# Altium schematic sheet Ethernet.SchDoc(Ethernet)
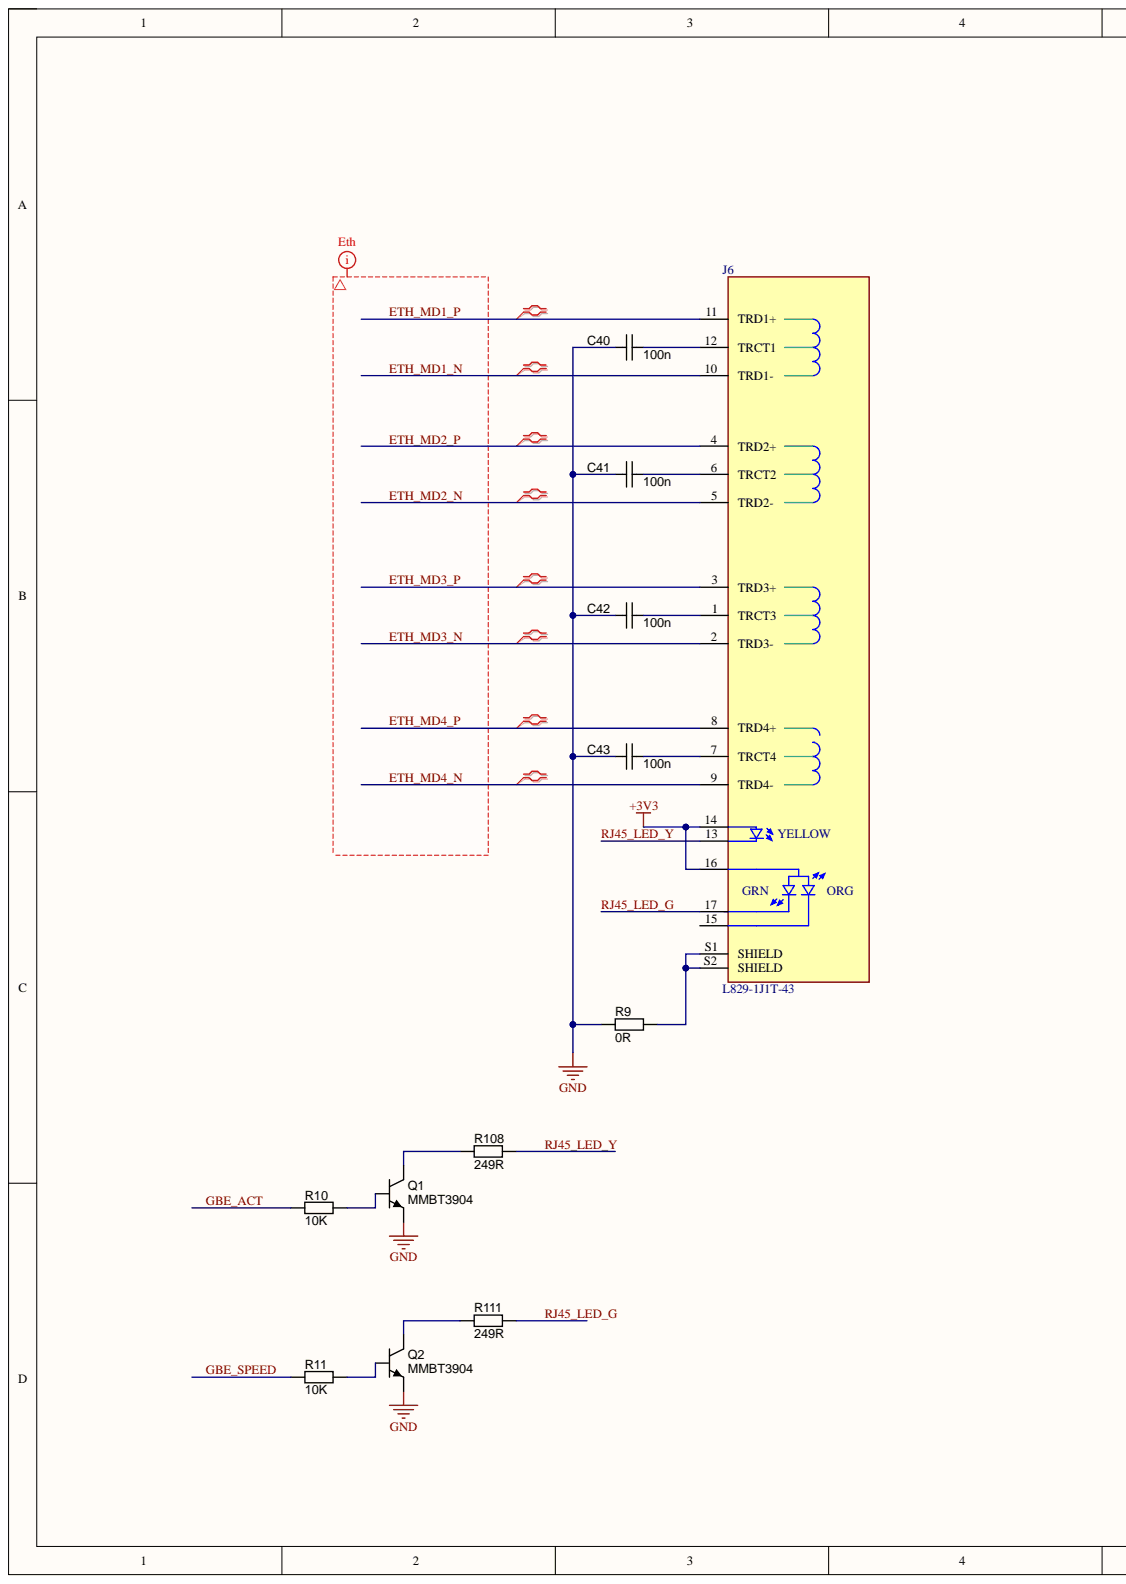
[diagram p0: Ethernet.SchDoc, left]
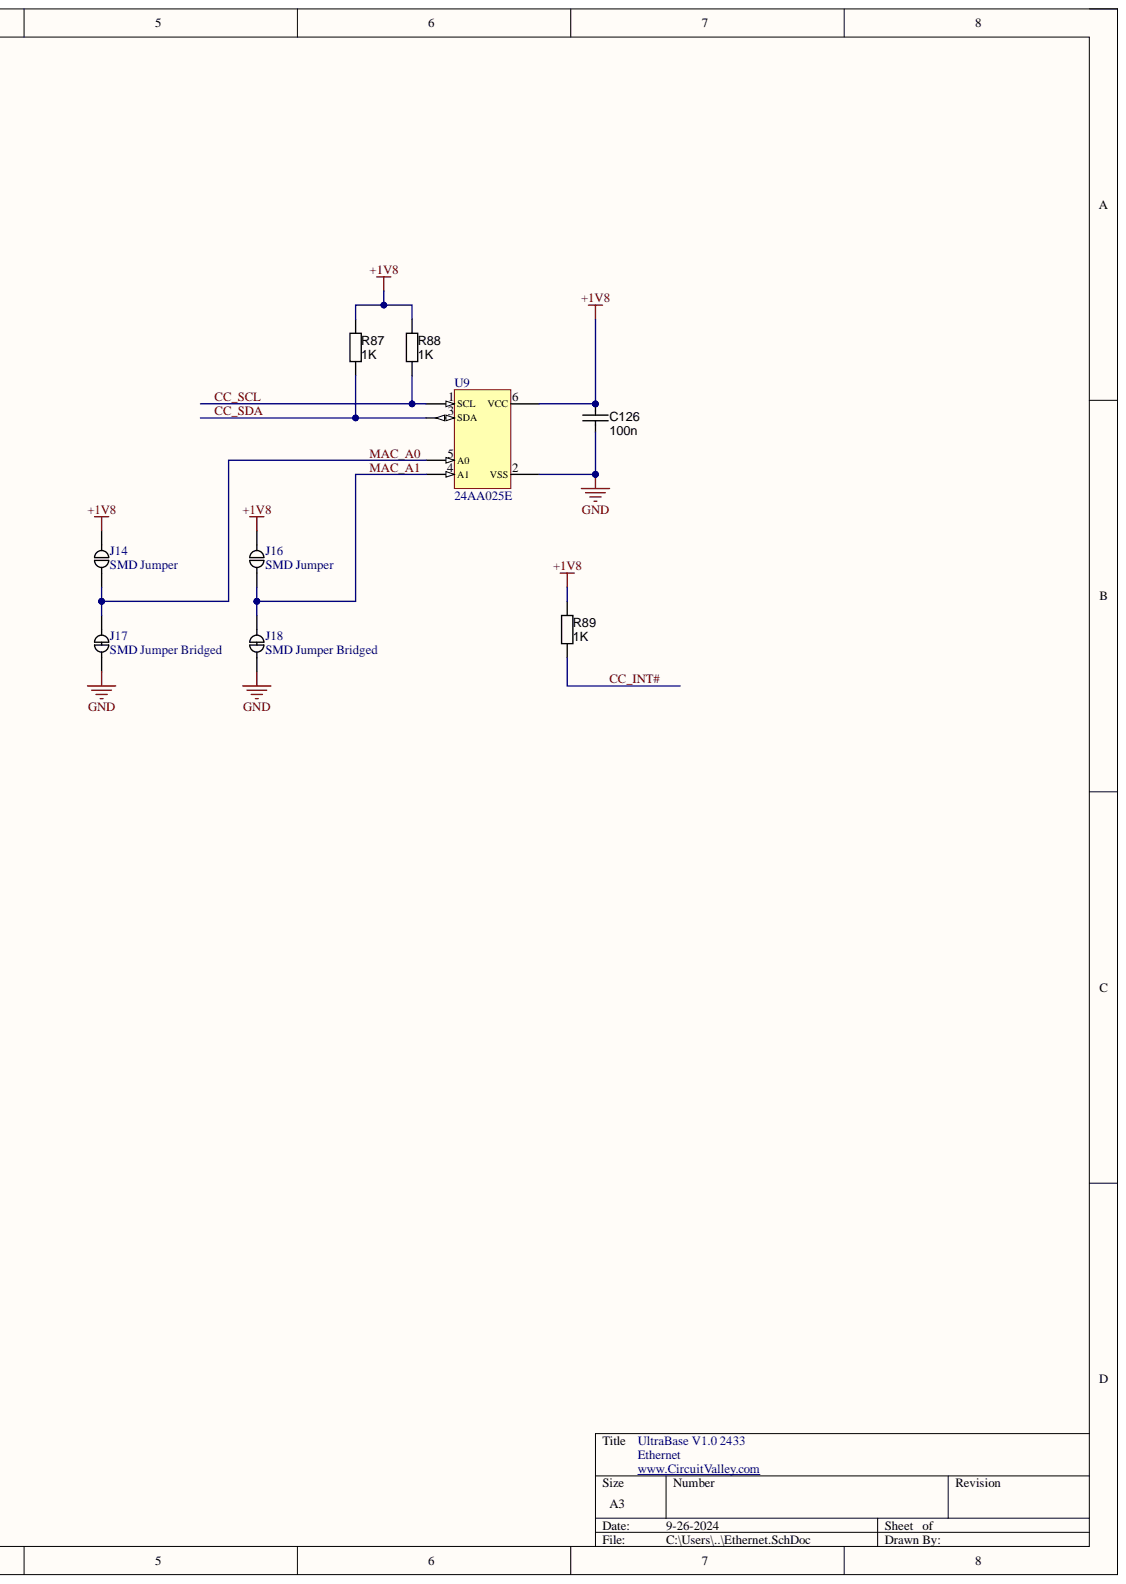
[diagram p1: Ethernet.SchDoc, right]

## Parts
C40  100n  [CAPC1608N]
C41  100n  [CAPC1608N]
C42  100n  [CAPC1608N]
C43  100n  [CAPC1608N]
C126  100n  [CAPC1608N]
J6  L829-1J1T-43  [L829-1J1T-43]
J14  SMD Jumper  [SMD_Jumper]
J16  SMD Jumper  [SMD_Jumper]
J17  SMD Jumper Bridged  [SMD_Jumper_bridge]
J18  SMD Jumper Bridged  [SMD_Jumper_bridge]
Q1  Manufacturer Part Number 1: MMBT3904  [SOT23-3]  (hidden comment: NPN_MMBT3904)
Q2  Manufacturer Part Number 1: MMBT3904  [SOT23-3]  (hidden comment: NPN_MMBT3904)
R9  value 0R  [RESC1608N]  (hidden comment: RES_NL_0603)
R10  value 10K  [RESC1608N]  (hidden comment: RES_NL_0603)
R11  value 10K  [RESC1608N]  (hidden comment: RES_NL_0603)
R87  value 1K  [RESC1608N]  (hidden comment: RES_NL_0603)
R88  value 1K  [RESC1608N]  (hidden comment: RES_NL_0603)
R89  value 1K  [RESC1608N]  (hidden comment: RES_NL_0603)
R108  value 249R  [RESC1608N]  (hidden comment: RES_NL_0603)
R111  value 249R  [RESC1608N]  (hidden comment: RES_NL_0603)
U9  24AA025E  [SOT23-6]

## Nets
+1V8: C126.2 J14.2 J16.2 R87.2 R88.2 R89.2 U9.6(VCC)
+3V3: J6.14 J6.16
GND: C40.1 C41.1 C42.1 C43.1 C126.1 J17.1 J18.1 Q1.2 Q2.2 R9.1 U9.2(VSS)
CC_INT#: R89.1
CC_SCL: R88.1 U9.1(SCL)
CC_SDA: R87.1 U9.3(SDA)
ETH_MD1_N: J6.10(TRD1-)
ETH_MD1_P: J6.11(TRD1+)
ETH_MD2_N: J6.5(TRD2-)
ETH_MD2_P: J6.4(TRD2+)
ETH_MD3_N: J6.2(TRD3-)
ETH_MD3_P: J6.3(TRD3+)
ETH_MD4_N: J6.9(TRD4-)
ETH_MD4_P: J6.8(TRD4+)
GBE_ACT: R10.1
GBE_SPEED: R11.1
MAC_A0: J14.1 J17.2 U9.5(A0)
MAC_A1: J16.1 J18.2 U9.4(A1)
RJ45_LED_G: J6.17 R111.2
RJ45_LED_Y: J6.13 R108.2
NetC40_2: C40.2 J6.12(TRCT1)
NetC41_2: C41.2 J6.6(TRCT2)
NetC42_2: C42.2 J6.1(TRCT3)
NetC43_2: C43.2 J6.7(TRCT4)
NetJ6_S1: J6.S1(SHIELD) J6.S2(SHIELD) R9.2
NetQ1_1: Q1.1 R10.2
NetQ1_3: Q1.3 R108.1
NetQ2_1: Q2.1 R11.2
NetQ2_3: Q2.3 R111.1

Not connected: J6 15

## Library data of the parts
C40-C43 C126 = CAPC_1n_0603_100V: Capacitor SMD Cer 1nF 10% 100V X7R 0603; Manufacturer 1: Murata Electronics North America; Manufacturer Part Number 1: GCM188R72A102KA37D; Material: ceramic; Ratings: 100V; X7R; Component Kind: Standard; ComponentLink1Description: http://search.murata.co.jp/Ceramy/image/img/PDF/ENG/GCM188R72A102KA37.pdf; ComponentLink1URL: http://search.murata.co.jp/Ceramy/image/img/PDF/ENG/GCM188R72A102KA37.pdf; Library Name: PBL - Capacitors.SCHLIB; Operating Temperature: -55°C ~ 125°C; Package / Case: 0603 (1608 metric); Size / Dimension: 0.063" L x 0.031" W (1.60mm x 0.80mm); Supplier 1: Digi-Key; Supplier Part Number 1: 490-4780-1-ND; Thickness (Max): 0.035" (0.90mm); Tolerance: ±10%; Value: 100n
J6 = L829-1J1T-43: MagJack 1000BASE-T | 1X1 | 3w-OG/Y | Tab Up | Low Profile | RoHS; MF: Bel Fuse; Availability: Unavailable; Component Kind: Standard; MP: L829-1J1T-43; Package: None; Price: None; SnapEDA_Link: https://www.snapeda.com/parts/L829-1J1T-43/Bel%20Fuse%20Inc./view-part/562341/?ref=snap; Library Name: cvlib.SchLib
J14 J16 = SMD_Jumper: Component Kind: Net Tie; Description: SMD Jumper; Library Name: cvlib.SchLib
J17 J18 = SMD_Jumper_Bridged: SMD Jumper; Component Kind: Net Tie; Library Name: cvlib.SchLib
Q1 Q2 = NPN_MMBT3904: Transistor SMD NPN 40V 0.2A 350mW SOT-23; Manufacturer 1: Fairchild Semiconductor; Material: Si; Ratings: 40V; 200mA; 350mW; Component Kind: Standard; Library Name: PBL - Transistors.SCHLIB; Mounting Type: Surface Mount; Package / Case: SOT-23; Supplier 1: Digi-Key; Supplier Part Number 1: MMBT3904FSCT-ND; Transistor Type: NPN
R9-R11 R87-R89 R108 R111 = RES_NL_0603: Resistor, SMD chip; Manufacturer 1: Royal Ohm; Ratings: 1/10 W; Tolerance: ±5%; Component Kind: Standard; Library Name: PBL - Resistors.SCHLIB; Package / Case: 0603 (1608 metric)
U9 = 24AA025E: MAC EEPROM; Component Kind: Standard; Library Name: cvlib.SchLib
